# Altium schematic sheet pir.SchDoc
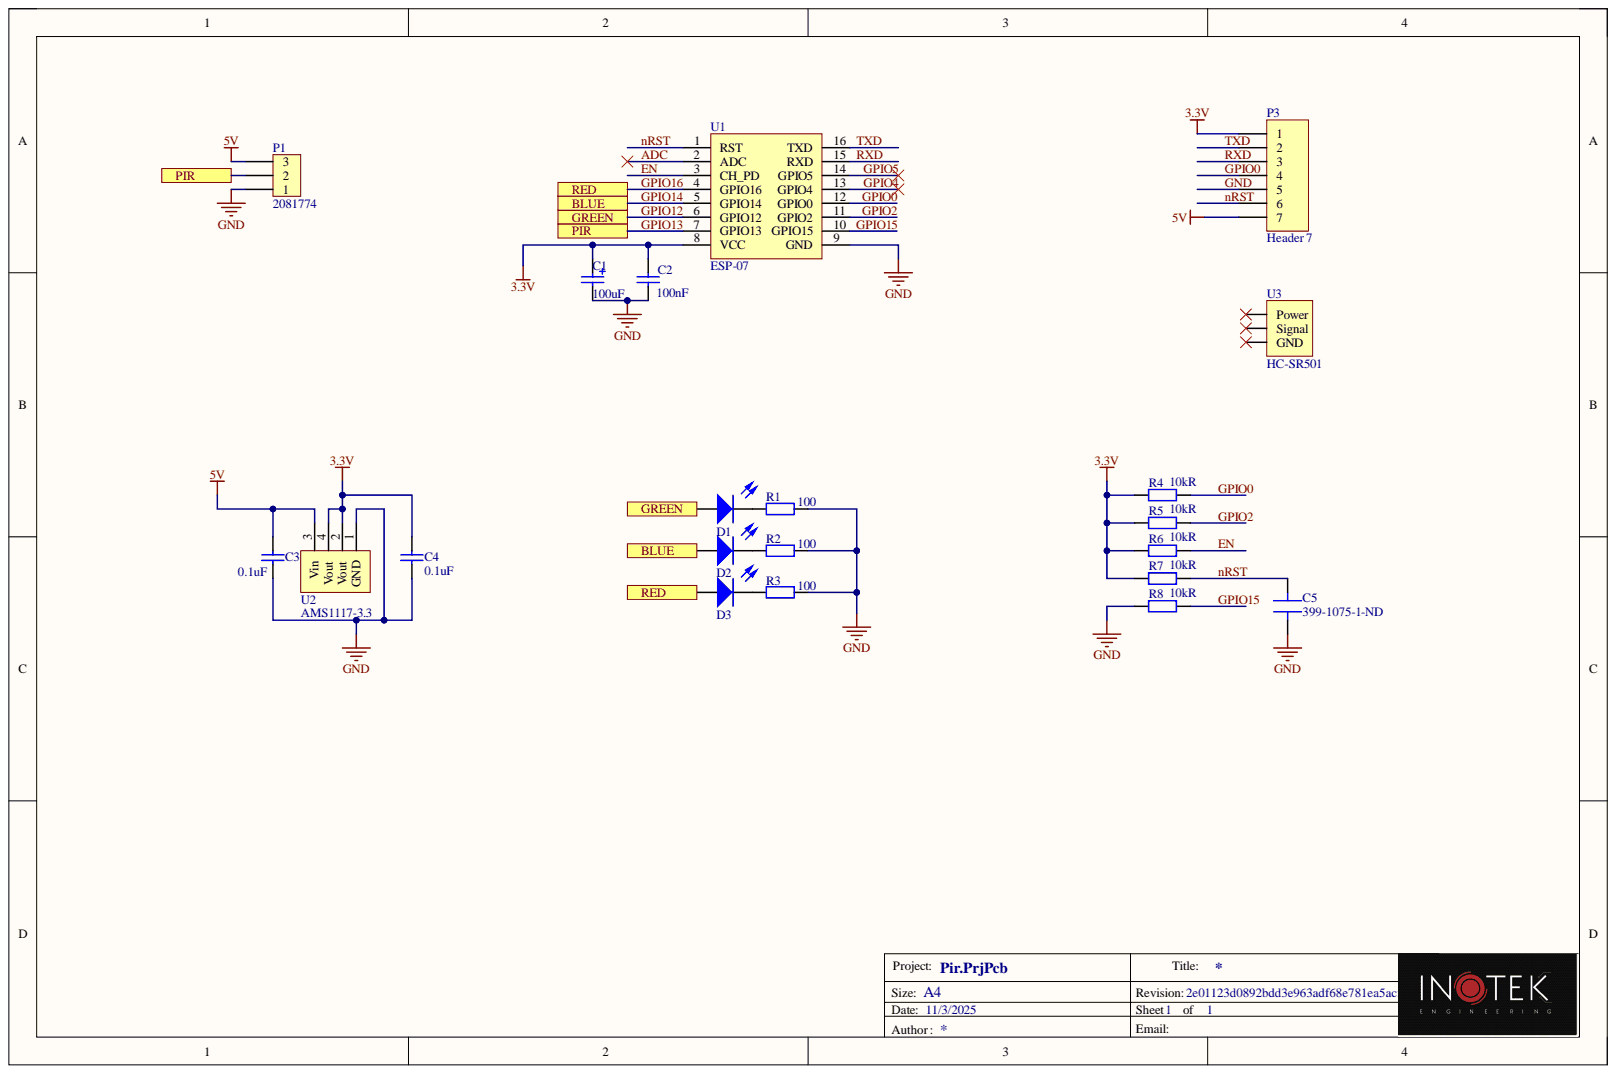
[diagram p0: pir.SchDoc]

## Parts
C1  Capacitance: 100uF  [CAP3528X210N]  (hidden comment: TAJB107M006RNJ)
C2  value 100nF  Capacitance: 100nF  [CAP0603]  (hidden comment: C1608X7R1H104K)
C3  value 0.1uF  [CAP0603]  (hidden comment: CC0603KPX7R7BB104)
C4  value 0.1uF  [CAP0603]  (hidden comment: CC0603KPX7R7BB104)
C5  399-1075-1-ND  [CAP0603]
D1  150060RS75000  [LED0603]  (value not drawn)
D2  150060RS75000  [LED0603]  (value not drawn)
D3  150060RS75000  [LED0603]  (value not drawn)
P1  Supplier Part Number 1: 2081774  [CONN_RCPT3RA]  (hidden comment: SSQ-103-02-T-S-RA)
P3  Header 7  [HDR1X7]
R1  value 100  [RES0603]  (hidden comment: WR06X101JTL)
R2  value 100  [RES0603]  (hidden comment: WR06X101JTL)
R3  value 100  [RES0603]  (hidden comment: WR06X101JTL)
R4  Resistance: 10kR  [RES0603]  (hidden comment: RMCF0603FT10K0)
R5  Resistance: 10kR  [RES0603]  (hidden comment: RMCF0603FT10K0)
R6  Resistance: 10kR  [RES0603]  (hidden comment: RMCF0603FT10K0)
R7  Resistance: 10kR  [RES0603]  (hidden comment: RMCF0603FT10K0)
R8  Resistance: 10kR  [RES0603]  (hidden comment: RMCF0603FT10K0)
U1  ESP-07  [ESP_07]
U2  AMS1117-3.3  [SOT223]
U3  HC-SR501  [HC-SR501]

## Ports
BLUE, GREEN, PIR, RED

## Nets
3.3V: C1.1 C2.1 C4.1 P3.1 R4.1 R5.1 R6.1 R7.1 U1.8(VCC) U2.2(Vout) U2.4(Vout)
5V: C3.1 P1.3 P3.7 U2.3(Vin)
ADC: U1.2(ADC)
EN: R6.2 U1.3(CH_PD)
GND: C1.2 C2.2 C3.2 C4.2 C5.1 P1.1 P3.5 R1.2 R2.2 R3.2 R8.1 U1.9(GND) U2.1(GND)
GPIO0: P3.4 R4.2 U1.12(GPIO0)
GPIO2: R5.2 U1.11(GPIO2)
GPIO4: U1.13(GPIO4)
GPIO5: U1.14(GPIO5)
GPIO12: D1.2 U1.6(GPIO12) ; port GREEN
GPIO13: P1.2 U1.7(GPIO13) ; port PIR
GPIO14: D2.2 U1.5(GPIO14) ; port BLUE
GPIO15: R8.2 U1.10(GPIO15)
GPIO16: D3.2 U1.4(GPIO16) ; port RED
nRST: C5.2 P3.6 R7.2 U1.1(RST)
RXD: P3.3 U1.15(RXD)
TXD: P3.2 U1.16(TXD)
NetD1_1: D1.1 R1.1
NetD2_1: D2.1 R2.1
NetD3_1: D3.1 R3.1

Not connected: U3 1 2 3

## Library data of the parts
C1 = TAJB107M006RNJ: CAP TANT 100UF 20% 6.3V SMD; Manufacturer 1: Kyocera AVX; Dissipation Factor: 10%; ESR (Equivalent Series Resistance): 1.7R; Leakage Current: 6.3uA; Polarity: Polar; Case Code (Imperial): 1210; Case Code (Metric): 3528; Case/Package: 1210; Category: Tantalum Capacitors; Component Kind: Standard; ComponentLink1Description: https://datasheet.ciiva.com/19458/1235709-19458485.pdf?src-supplier=Jameco; ComponentLink1URL: https://datasheet.ciiva.com/19458/1235709-19458485.pdf?src-supplier=Jameco; ComponentLink2Description: https://datasheet.ciiva.com/3004/taj-3004435.pdf?src-supplier=Avnet; ComponentLink2URL: https://datasheet.ciiva.com/3004/taj-3004435.pdf?src-supplier=Avnet; ComponentLink3Description: https://datasheet.ciiva.com/3004/taj-3004436.pdf?src-supplier=Avnet; ComponentLink3URL: https://datasheet.ciiva.com/3004/taj-3004436.pdf?src-supplier=Avnet; ComponentLink4Description: https://datasheet.ciiva.com/16632/taj-16632598.pdf?src-supplier=Avnet+Europe; ComponentLink4URL: https://datasheet.ciiva.com/16632/taj-16632598.pdf?src-supplier=Avnet+Europe; ComponentLink5Description: https://datasheet.ciiva.com/12883/taj-27497-12883624.pdf?src-supplier=Mouser; ComponentLink5URL: https://datasheet.ciiva.com/12883/taj-27497-12883624.pdf?src-supplier=Mouser; ComponentLink6Description: https://datasheet.ciiva.com/264/taj-264217.pdf?src-supplier=Digi-Key; ComponentLink6URL: https://datasheet.ciiva.com/264/taj-264217.pdf?src-supplier=Digi-Key; ComponentLink7Description: https://datasheet.ciiva.com/264/taj-264218.pdf?src-supplier=Digi-Key; ComponentLink7URL: https://datasheet.ciiva.com/264/taj-264218.pdf?src-supplier=Digi-Key; ComponentLink8Description: https://datasheet.ciiva.com/264/taj-264219.pdf?src-supplier=Digi-Key; ComponentLink8URL: https://datasheet.ciiva.com/264/taj-264219.pdf?src-supplier=Digi-Key; Depth: 2.8mm; Features: General Purpose; Height: 2.1mm; Height - Seated (Max): 0.083inch; Lead Free: Lead Free; Length: 3.5mm; Manufacturer Part Number 1: TAJB107M006RNJ; Max Operating Temperature: 125°C; Min Operating Temperature: -55°C; Mount: Surface Mount; Number of Pins: 2; Package Quantity: 1; Packaging: Tape and Reel; Pricing 1: 1=1.09000, 10=0.86300, 100=0.64690, 500=0.48876, 1000=0.43125 (USD); Radiation Hardening: No; REACH SVHC: No SVHC; RoHS: Yes; Stock 1: 9997; Supplier 1: Digi-Key; Supplier Part Number 1: 478-3877-1-ND; Termination: SMD/SMT; Tolerance: 20%; Voltage Rating: 6.3V; Voltage Rating (DC): 6.3V; Width: 2.8mm; Library Name: InotekLib.SchLib
C2 = C1608X7R1H104K: Cap, 100 nF 50 V, Ceramic, X7R, 0603; Manufacturer: TDK; Tolerance: 10%; Voltage: 50V; Case Code (Imperial): 0603; Case Code (Metric): 1608; Case/Package: 0603; Component Kind: Standard; ComponentLink1Description: http://www.jameco.com/Jameco/Products/ProdDS/1847182.pdf?src-supplier=Jameco; ComponentLink1URL: http://www.jameco.com/Jameco/Products/ProdDS/1847182.pdf?src-supplier=Jameco; ComponentLink2Description: http://www.farnell.com/datasheets/1571323.pdf?src-supplier=Element14; ComponentLink2URL: http://www.farnell.com/datasheets/1571323.pdf?src-supplier=Element14; Depth: 0.8mm; Dielectric: X7R; Length: 1.6mm; Manufacturer Part Number: C1608X7R1H104K; Max Operating Temperature: 125°C; Min Operating Temperature: -55°C; Mount: Surface Mount; Number of Pins: 2; Package Quantity: 4000; Packaging: Tape and Reel; Radiation Hardening: No; REACH SVHC: No SVHC; Stock 2: 0; Supplier 1: Digi-Key; Supplier 2: Arrow; Supplier Part Number 1: 445-5666-1-ND; Supplier Part Number 2: C1608X7R1H104K; Termination: SMD/SMT; Voltage Rating: 50V; Library Name: InotekLib.IntLib
C3 C4 = CC0603KPX7R7BB104: CAP CER 0.1UF 16V X7R 0603; Manufacturer: Yageo; Component Kind: Standard; Manufacturer Part Number: CC0603KPX7R7BB104; Supplier 1: Digi-Key; Supplier Part Number 1: 311-1335-6-ND; Library Name: InotekLib.IntLib
C5 = 399-1075-1-ND: CAP CER 470PF 50V X7R 0603; Manufacturer 1: KEMET; Manufacturer Part Number 1: C0603C471K5RACTU; Dielectric Material: Ceramic; Dissipation Factor: 2.5%; Tolerance: 10%; Capacitance: 470pF; Case Code (Imperial): 0603; Case Code (Metric): 1608; Case/Package: 0603; Category: Ceramic Capacitors; Component Kind: Standard; ComponentLink1Description: https://datasheet.ciiva.com/16623/datasheetpn-c0603c471k5rac7867-16623634.pdf?src-supplier=Avnet+Europe; ComponentLink1URL: https://datasheet.ciiva.com/16623/datasheetpn-c0603c471k5rac7867-16623634.pdf?src-supplier=Avnet+Europe; Contact Plating: Tin,Matte; Depth: 0.8mm; Dielectric: X7R; Height: 0.8mm; Lead Pitch: 0.7mm; Length: 1.6mm; Max Operating Temperature: 125°C; Min Operating Temperature: -55°C; Mount: Surface Mount; Number of Pins: 2; Package Quantity: 4000; Packaging: Tape and Reel; Pricing 1: 1=0.10000, 10=0.06300, 100=0.02790, 500=0.01990, 1000=0.01564 (USD); Radiation Hardening: No; REACH SVHC: No SVHC; RoHS: Yes; Stock 1: 174531; Supplier 1: Digi-Key; Supplier Part Number 1: 399-1075-1-ND; Termination: SMD/SMT; Thickness: 0.034inch; Voltage: 50V; Voltage Rating: 50V; Voltage Rating (DC): 50V; Width: 0.8mm; Library Name: InotekLib.IntLib
D1-D3 = 150060RS75000: WURTH ELEKTRONIK - 150060RS75000 - LED, 0603, RED, 250MCD, 625NM; Manufacturer: Wurth Electronics; Forward Current: 30mA; Forward Voltage: 2V; Lens Style: Clear,Rectangular; Test Current: 20mA; Viewing Angle: 140°; Case Code (Imperial): 0603; Case Code (Metric): 1608; Case/Package: 0603; Color: Red; Component Kind: Standard; ComponentLink1Description: https://datasheet.ciiva.com/29563/150060rs75000-29563040.pdf?src-supplier=Digi-Key; ComponentLink1URL: https://datasheet.ciiva.com/29563/150060rs75000-29563040.pdf?src-supplier=Digi-Key; ComponentLink2Description: https://datasheet.ciiva.com/29279/759428-da-01-en-chipled-0603-w-kl-rot-150060rs75000-29279196.pdf?src-supplier=Conrad; ComponentLink2URL: https://datasheet.ciiva.com/29279/759428-da-01-en-chipled-0603-w-kl-rot-150060rs75000-29279196.pdf?src-supplier=Conrad; Dominant Wavelength: 625nm; Height: 0.7mm; Length: 1.6mm; Luminous Intensity: 250mcd; Manufacturer Part Number: 150060RS75000; Max Operating Temperature: 85°C; Min Operating Temperature: -40°C; Mount: Surface Mount; Package Quantity: 1; Packaging: Cut Tape; Pricing 1: 1=0.14000, 50=0.13300, 100=0.11400, 500=0.09900 (USD); REACH SVHC: Unknown; RoHS: Yes; Stock 1: 91800; Supplier 1: Digi-Key; Supplier Part Number 1: 732-4978-1-ND; Wavelength: 625nm; Width: 0.8mm; Library Name: InotekLib.IntLib
P1 = SSQ-103-02-T-S-RA: CONN RCPT 3POS 0.1 TIN PCB R/A; Manufacturer: Samtec; Connector Type: Receptacle; Contact Resistance: 10mR; Gender: Female; Max Current Rating: 7.6A; Max Voltage Rating (AC): 550V; Number of Contacts: 3; Pitch: 2.54mm; Category: Connectors; Component Kind: Standard; ComponentLink1Description: https://datasheet.ciiva.com/749/ssq-1xx-xx-xxx-x-xx-xxx-xx-x-mkt-749184.pdf?src-supplier=Digikey; ComponentLink1URL: https://datasheet.ciiva.com/749/ssq-1xx-xx-xxx-x-xx-xxx-xx-x-mkt-749184.pdf?src-supplier=Digikey; Contact Finish - Mating: TIN; Contact Material: Bronze; Contact Plating: Tin; Contact Shape: Square; Contact Type: Forked; Fastening Type: Pull; Flammability Rating: UL94 V-0; Height: 2.41mm; Housing Color: Black; Housing Material: Plastic; Insulation Color: Black; Insulation Material: Polymer; Lead Free: Lead Free; Length: 8.13mm; Manufacturer Part Number: SSQ-103-02-T-S-RA; Max Operating Temperature: 105°C; Min Operating Temperature: -55°C; Mount: Through Hole; Number of Positions: 3; Number of Rows: 1; Orientation: Right Angle; Packaging: Bulk; Pricing 1: 1=0.66, 10=0.5, 50=0.46, 100=0.42, 250=0.39 (GBP); Radiation Hardening: No; RoHS: Yes; RoHS Compliant: Yes; Series: SSQ; Stock 1: 74; Supplier 1: Rs; Termination: Solder; Voltage Rating (AC): 550V; Width: 8.51mm; Library Name: InotekLib.IntLib
P3 = Header 7: Header, 7-Pin; Component Kind: Standard; LatestRevisionDate: 17-Jul-2002; LatestRevisionNote: Re-released for DXP Platform.; Library Name: Miscellaneous Connectors.IntLib; Signal Integrity: Connector; Publisher: Altium Limited
R1-R3 = WR06X101JTL: RES 100 OHM 5% 1/10W 0603; Manufacturer: Walsin Technologies; Category: Chip SMD Resistors; Component Kind: Standard; ComponentLink1Description: https://datasheet.ciiva.com/18163/getdatasheetpartid-414062-18163861.pdf?src-supplier=Verical; ComponentLink1URL: https://datasheet.ciiva.com/18163/getdatasheetpartid-414062-18163861.pdf?src-supplier=Verical; Manufacturer Part Number: WR06X101JTL; Pricing 1: 1=0.1, 10=0.012, 50=0.0064, 100=0.0052, 500=0.0033, 1000=0.00278 (USD); RoHS: Yes; Stock 1: 68723; Supplier 1: Digikey; Supplier Part Number 1: 1292-WR06X101JTLCT-ND; Library Name: InotekLib.IntLib
R4-R8 = RMCF0603FT10K0: RES 10K OHM 1% 1/10W 0603; Manufacturer 1: Stackpole Electronics; Max Power Dissipation: 100mW; Temperature Coefficient: 100ppm/°C; Voltage Rating: 75V; Case Code (Imperial): 0603; Case Code (Metric): 1608; Case/Package: 0603; Component Kind: Standard; ComponentLink1Description: http://components.arrow.com/part/dataview/43747082S6756917N1174?src-supplier=Arrow+Electronics; ComponentLink1URL: http://components.arrow.com/part/dataview/43747082S6756917N1174?src-supplier=Arrow+Electronics; ComponentLink2Description: https://datasheet.octopart.com/RMCF0603FT10K0-Stackpole-Electronics-datasheet-11547379.pdf?src-supplier=Future+Electronics; ComponentLink2URL: https://datasheet.octopart.com/RMCF0603FT10K0-Stackpole-Electronics-datasheet-11547379.pdf?src-supplier=Future+Electronics; ComponentLink3Description: https://www.seielect.com/catalog/SEI-RMCF_RMCP.pdf?src-supplier=Avnet; ComponentLink3URL: https://www.seielect.com/catalog/SEI-RMCF_RMCP.pdf?src-supplier=Avnet; ComponentLink4Description: http://www.seielect.com/catalog/sei-rmc.pdf?src-supplier=Future+Electronics; ComponentLink4URL: http://www.seielect.com/catalog/sei-rmc.pdf?src-supplier=Future+Electronics; ComponentLink5Description: https://www.verical.com/server-webapp/html/getDatasheet?partID=360913&src-supplier=Verical; ComponentLink5URL: https://www.verical.com/server-webapp/html/getDatasheet?partID=360913&src-supplier=Verical; ComponentLink6Description: https://www.seielect.com/catalog/sei-rmcf_rmcp.pdf?src-supplier=Digi-Key; ComponentLink6URL: https://www.seielect.com/catalog/sei-rmcf_rmcp.pdf?src-supplier=Digi-Key; ComponentLink7Description: https://datasheet.octopart.com/RMCF0603FT10K0-Stackpole-Electronics-datasheet-155966809.pdf?src-supplier=Master+Electronics; ComponentLink7URL: https://datasheet.octopart.com/RMCF0603FT10K0-Stackpole-Electronics-datasheet-155966809.pdf?src-supplier=Master+Electronics; Construction: Rectangular; Contact Plating: Tin,Matte; Depth: 0.8mm; Features: Automotive AEC-Q200; Height: 0.022inch; Lead Free: Lead Free; Length: 1.55mm; Manufacturer Part Number 1: RMCF0603FT10K0; Max Operating Temperature: 155°C; Military Standard: Not; Min Operating Temperature: -55°C; Mount: Surface Mount; Number of Terminations: 2; Packaging: Tape and Reel; Power Rating: 100mW; Pricing 1: 5000=0.0029, 10000=0.0025, 25000=0.0022, 35000=0.0021, 50000=0.0019, 125000=0.0019 (USD); Radiation Hardening: No; Ratings: AEC-Q200; RoHS: Yes; RoHS Compliant: Yes; Series: RMCF; Size Code: 0603; Stock 1: 14235000; Supplier 1: Arrow Electronics; Supplier Part Number 1: RMCF0603FT10K0; Tolerance: 1%; Width: 0.031inch; Library Name: InotekLib.IntLib
U1 = ESP-07: ESP8266 WIFI 802.11B/G/N 160MBIT; Manufacturer 1: RF Solutions; Manufacturer Part Number 1: ESP-07S; Component Kind: Standard; ComponentLink1Description: https://www.rfsolutions.co.uk/downloads/1494602250ESP-07S_Datasheet.pdf?src-supplier=Digi-Key; ComponentLink1URL: https://www.rfsolutions.co.uk/downloads/1494602250ESP-07S_Datasheet.pdf?src-supplier=Digi-Key; Pricing 1: 1=9.89000, 10=9.06600, 25=8.24160, 100=7.41740, 250=6.79932, 500=6.18120, 1000=5.56308 (USD); RoHS: No; Stock 1: 14; Supplier 1: Digi-Key; Supplier Part Number 1: ESP-07S-ND; Library Name: InotekLib.IntLib
U3 = HC-SR501: Mini PIR (Passive Infra Red) motion sensor module.; Component Kind: Standard; Library Name: InotekLib.IntLib
U2 = AMS1117-3.3: Component Kind: Standard; Library Name: MyLib.SchLib
